# Altium schematic sheet 04-HallEffectSensor.SchDoc
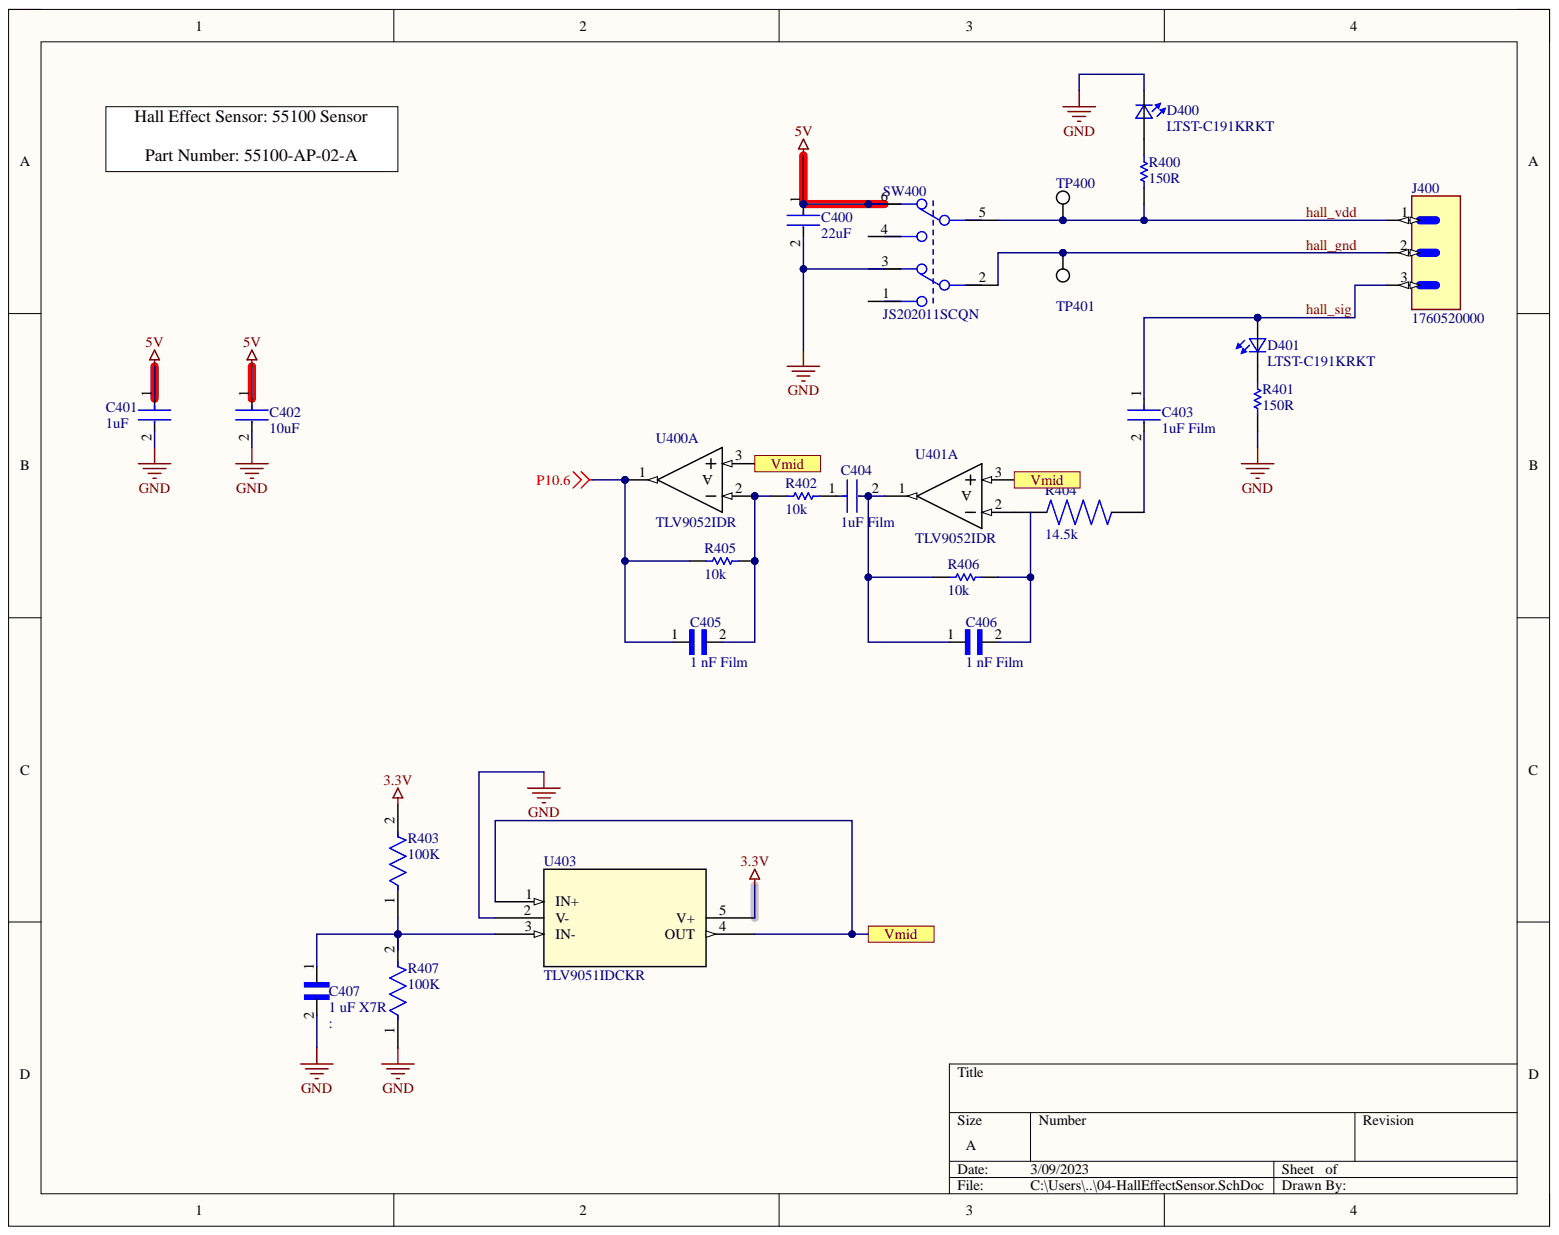
[diagram p0: 04-HallEffectSensor.SchDoc]

## Parts
C400  22uF  [FP-GRM21B-0_15-MFG]
C401  1uF  [FP-CC0805-0_85-MFG]
C402  value 10uF  Capacitance: 10uF  [FP-C0805C-DG-MFG]  (hidden comment: C0805C106K8PACTU)
C403  1uF Film  Capacitance: 1uF  [FP-R82DC4100DQ60J-MFG]
C404  1uF Film  Capacitance: 1uF  [FP-R82DC4100DQ60J-MFG]
C405  1 nF Film  [CAPRR500W50L730T250H650]
C406  1 nF Film  [CAPRR500W50L730T250H650]
C407  1 uF X7R  [CAPC2012X140N]
D400  LTST-C191KRKT  [0603]
D401  LTST-C191KRKT  [0603]
J400  1760520000  [1760520000]
R400  150R  [RESC3216X70X50ML20T25]
R401  150R  [RESC2013X60X40NL20T25]
R402  10k  [RESC2013X60X35ML10T20]
R403  100K  [FP-RC0805-0_6-IPC_C]
R404  14.5k  [RESC2012X60N]
R405  10k  [RESC2013X60X35ML10T20]
R406  10k  [RESC2013X60X35ML10T20]
R407  100K  [FP-RC0805-0_6-IPC_C]
SW400  JS202011SCQN  [FP-JS202011SCQN-MFG]
TP400  5019  [KSTN-5019_V]  (value not drawn)
TP401  5019  [KSTN-5019_V]  (value not drawn)
U400  TLV9052IDR  [D0008A_N]  (part A)
U401  TLV9052IDR  [D0008A_N]  (part A)
U403  TLV9051IDCKR  [SOT_1IDCKR_TEX]

## Ports
Vmid

## Nets
3.3V: R403.2 U403.5(V+)
5V: C400.1 C401.1 C402.1 SW400.6
GND: C400.2 C401.2 C402.2 C407.2 D400.1 R401.1 R407.1 U403.2(V-)
P10.6: C405.1 R405.1 U400.1
hall_gnd: J400.2 SW400.2 TP401.1
hall_sig: C403.1 D401.2 J400.3
hall_vdd: J400.1 R400.1 SW400.5 TP400.1
Vmid: U400.3 U401.3 U403.1(IN+) U403.4(OUT) ; port Vmid
NetC403_2: C403.2 R404.2
NetC404_1: C404.1 R402.2
NetC404_2: C404.2 C406.1 R406.1 U401.1
NetC405_2: C405.2 R402.1 R405.2 U400.2
NetC406_2: C406.2 R406.2
NetC407_1: C407.1 R403.1 R407.2 U403.3(IN-)
NetD400_2: D400.2 R400.2
NetD401_1: D401.1 R401.2
NetR404_1: R404.1 U401.2

Not connected: SW400 1 3 4

## Library data of the parts
C400 = CMP-2007-03385-2: Manufacturer: Murata; Manufacturer Part Number: GRM21BR60J226ME39L; Dielectric: X5R; Material: Ceramic; Tolerance: 20%; Voltage: 6.3V; Capacitance: 22uF; Case Code (Imperial): 0805; Case Code (Metric): 2012; Case/Package: 0805; Category: Ceramic Capacitors; Component Kind: Standard; Construction: Flat; Depth: 1.25mm; Description: 22uF; Height: 1.25mm; Lead Free: Lead Free; Length: 2mm; Library Name: Altium Content Vault; Max Operating Temperature: 85°C; Min Operating Temperature: -55°C; Mount: Surface Mount; Package Quantity: 1; Packaging: Tape and Reel; Pricing 1: 1=0.37000, 10=0.25600, 50=0.17940, 100=0.15380, 500=0.11532, 1000=0.09739 (USD); Radiation Hardening: No; REACH SVHC: No SVHC; Reach SVHC Compliant: Yes; RoHS: Yes; RoHS Compliant: Yes; Series: GRM; Stock 1: 2705091; Supplier 1: Digi-Key; Supplier Part Number 1: 490-1719-1-ND; Termination: SMD/SMT; Thickness: 0.053inch; Voltage Rating: 6.3V; Voltage Rating (DC): 6.3V; Width: 0.049inch
C401 = CMP-03422-000182-1: CAP CER 1UF 10V X7R 0805; Manufacturer: Yageo; Manufacturer Part Number: CC0805KKX7R6BB105; Material: Ceramic; Tolerance: 0.1; Automotive: No; Capacitance: 1 uF; Category: Cap; Component Kind: Standard; ComponentLink2Description: Datasheet; ComponentLink2URL: http://www.yageo.com/documents/recent/UPY-GPHC_Y5V_6.3V-to-50V_8.pdf; Device Class L1: Passive Components; Device Class L2: Capacitors; Device Class L3: Ceramic Capacitors; Digikey Description: CAP CER 1UF 10V X7R 0805; Footprint Url: http://www.yageo.com/exep/pages/download/literatures/UPY-C_GEN_24.pdf; Height: 0.95mm; Ipc Land Pattern Name: CAPC200125X85; Lead Free: Yes; Library Name: Altium Content Vault; Mouser Description: Multilayer Ceramic Capacitors MLCC - SMD/SMT 1.0uF 10V X7R 10%; Octopart: https://octopart.com/cc0805kkx7r6bb105-yageo-42522859; Package: CC0805-0.85; RoHS: TRUE; Temperature: -30°C to +85°C; Temperature Characteristic: X7R; Temperature Range High: +85°C; Temperature Range Low: -30°C; Value: 1 uF; Voltage Rating: 10 V
C402 = CMP-2007-03243-2: CAP CER 10UF 10V X5R 0805; 3DS Part Number: 220-00001; Manufacturer: Kemet; Dielectric Material: Ceramic; Dissipation Factor: 10%; Temperature Coefficient: 15%; Automotive: No; Case Code (Imperial): 0805; Case Code (Metric): 2012; Case/Package: 0805; Category: Cap; Component Kind: Standard; ComponentLink2Description: Datasheet; ComponentLink2URL: https://content.kemet.com/datasheets/KEM_C1006_X5R_SMD.pdf; Construction: Flat; Contact Plating: Tin,Matte; Depth: 1.25mm; Device Class L1: Passive Components; Device Class L2: Capacitors; Device Class L3: Ceramic Capacitors; Dielectric: X5R; Digikey Description: CAP CER 10UF 10V X5R 0805; Height: 1.4mm; Ipc Land Pattern Name: CAPC200125X125; Lead Free: Yes; Length: 2mm; Library Name: Altium Content Vault; Manufacturer 1: KEMET; Manufacturer Part Number: C0805C106K8PACTU; Manufacturer Part Number 1: C0805C106K8PACTU; Material: Ceramic; Max Operating Temperature: 85°C; Min Operating Temperature: -55°C; Mount: Surface Mount; Mouser Description: CAP CER 10UF 10V X5R 0805; Number of Pins: 2; Octopart: https://octopart.com/c0805c106k8pactu-kemet-98697; Package: C0805C-DG; Package Quantity: 1; Packaging: Tape and Reel; Pricing 1: 1=0.14000, 10=0.10300, 50=0.05840, 100=0.04830, 500=0.03388, 1000=0.02805 (USD); Radiation Hardening: No; REACH SVHC: No SVHC; RoHS: TRUE; RoHS Compliant: Yes; Series: C; Stock 1: 8631846; Supplier 1: Digi-Key; Supplier Part Number 1: 399-C0805C106K8PAC7800CT-ND; Temperature: -55°C to +85°C; Temperature Characteristic: X5R; Temperature Range High: +85°C; Temperature Range Low: -55°C; Termination: SMD/SMT; Thickness: 0.055inch; Tolerance: 10%; Voltage: 10V; Voltage Rating: 10V; Voltage Rating (DC): 10V; Width: 1.2mm
C403 C404 = CMP-03022-000017-1: CAP FILM 1UF 5% 63VDC RADIAL; Dielectric: PET; Dissipation Factor: 80%; Insulation Resistance: 30R; Lead Diameter: 0.5mm; Life (Hours): 2000Hour; Type: General Purpose; Voltage: 63V; Voltage Rating (AC): 40V; Case/Package: Radial; Component Kind: Standard; Construction: RADIAL; Contact Plating: Tin; Depth: 5mm; Dielectric Material: PET; Height - Seated (Max): 0.398inch; Lead Pitch: 5mm; Length: 7.2mm; Library Name: Altium Content Vault; Max Operating Temperature: 105°C; Min Operating Temperature: -55°C; Mount: Through Hole; Package Quantity: 1700; Packaging: Tape and Reel; Radiation Hardening: No; REACH SVHC: No SVHC; RoHS Compliant: Yes; Series: R82; Termination: Radial; Tolerance: 5%; Voltage Rating: 63V; Voltage Rating (DC): 63V; Width: 5mm
C405 C406 = B32529C0102K289: 1000 pF Film Capacitor 40V 63V Polyester, Polyethylene Terephthalate (PET), Metallized - Stacked Radial; MF: TDK; Check_prices: https://www.snapeda.com/parts/B32529C0102K289/TDK/view-part/?ref=eda; Component Kind: Standard; Digi-Key_Part_Number: 495-2456-1-ND; Library Name: B32529C0102K289.SchLib; MP: B32529C0102K289; Package: None; Purchase-URL: https://pricing.snapeda.com/search/part/B32529C0102K289/?ref=eda; SnapEDA_Link: https://www.snapeda.com/parts/B32529C0102K289/TDK/view-part/?ref=snap; Supplier 1: Digi-Key; Supplier Part Number 1: 495-2456-1-ND
C407 = CL21B105KAFNNNE: 1ÂµF Â±10% 25V Ceramic Capacitor X7R 0805 (2012 Metric); MF: Samsung Electro-Mechanics; Arrow_Electronics-Purchase-URL: https://snapeda.com/shop?store=Arrow+Electronics&id=544120; Arrow_Europe-Purchase-URL: https://snapeda.com/shop?store=Arrow+Europe&id=544120; Component Kind: Standard; Digi-Key_Part_Number: 1276-1066-1-ND; DigiKey-Purchase-URL: https://snapeda.com/shop?store=DigiKey&id=544120; Library Name: CL21B105KAFNNNE.SchLib; MP: CL21B105KAFNNNE; Package: 0805 Samsung; SnapEDA_Link: https://www.snapeda.com/parts/CL21B105KAFNNNE/Samsung%20Electro-Mechanics/view-part/544120/?ref=snap; Supplier 1: Digi-Key; Supplier Part Number 1: 1276-1066-1-ND
D400 D401 = LTST-C191KRKT: http://octopart.com/ltst-c190krkt-lite-on-549893; Availability: Unavailable; Component Kind: Standard; Library Name: LTST-C191KRKT.SchLib; MP: LTST-C191KRKT; Package: None; Price: None; Supplier 1: Digi-Key; Supplier Part Number 1: 160-1447-1-ND
J400 = 1760520000: 3 Position Wire to Board Terminal Block Horizontal with Board 0.200 _5.08mm_ Through Hole; MF: Weidmuller; Availability: Unavailable; Component Kind: Standard; Library Name: SnapEDAsch.SchLib; MP: 1760520000; Package: None; Price: None; SnapEDA_Link: https://www.snapeda.com/parts/1760520000/Weidmuller/view-part/423520/?ref=snap; Supplier 1: Digi-Key; Supplier Part Number 1: 281-1883-ND
R400 = CMP-2003-04422-1: Manufacturer: Panasonic; Part Number: ERA8AEB151V; Component Kind: Standard; Library Name: Altium Content Vault
R401 = CMP-2001-00596-1: Manufacturer: Panasonic; Part Number: ERA6AEB151V; Component Kind: Standard; Library Name: Altium Content Vault
R402 R405 R406 = CMP-2000-05025-1: Chip Resistor, 10 KOhm, +/- 5%, 0.125 W, -55 to 155 degC, 0805 (2012 Metric), RoHS, Tape and Reel; Manufacturer: Yageo; Power Rating: 250mW; Product Range: RCSeries; Resistance: 10kohm; Resistor Case Style: 0805[2012Metric]; Resistor Element Type: ThickFilm; SVHC: ToBeAdvised; Temperature Coefficient: ±100ppm/degC; Voltage Rating: 150V; Automotive Qualification Standard: -; Component Kind: Standard; Datasheet URL: http://www.yageo.ru/pdf/RC0805.pdf; Datasheet Version: REV. 2, 09/2004; Library Name: Altium Content Vault; Manufacturer URL: http://www.yageo.com/; MSL: MSL1-Unlimited; Package Description: 2-Pin Surface Mounted Device, Body 2 x 1.25 mm; Package Reference: 805; Packaging: CutTape; Resistance Tolerance: ±5%
R403 R407 = CMP-2100-03668-5: RES 100K OHM 1% 1/8W 0805; Max Power Dissipation: 125mW; Resistance: 100kR; Temperature Coefficient: 100ppm/°C; Voltage Rating: 150V; Case Code (Imperial): 0805; Case Code (Metric): 2012; Case/Package: 0805; Component Kind: Standard; Construction: Rectangular; Depth: 1.25mm; Features: Moisture Resistant; Height: 0.024inch; Lead Free: Lead Free; Lead Pitch: 0.4mm; Length: 0.079inch; Library Name: Altium Content Vault; Max Operating Temperature: 155°C; Military Standard: Not; Min Operating Temperature: -55°C; Number of Pins: 2; Number of Terminations: 2; Package Quantity: 1; Packaging: Tape and Reel; Power Rating: 125mW; Resistance Tolerance: 1%; Resistor Type: Thick Film; RoHS Compliant: Yes; Series: RC; Size Code: 0805; Supplier: Digi-Key; Supplier Part Number: 311-100KCRCT-ND; Technology: THICK FILM; Termination: SMD/SMT; Tolerance: 1%; Voltage Rating (DC): 150V; Width: 0.049inch
R404 = RT0805DRE0714K5L: Availability: Unavailable; Component Kind: Standard; Library Name: RT0805DRE0714K5L.SchLib; MP: RT0805DRE0714K5L; Package: None; Price: None; Supplier 1: Digi-Key; Supplier Part Number 1: 311-2788-1-ND
SW400 = CMP-2000-05087-3: SWITCH SLIDE DPDT 300MA 6V; Actuator Color: Black; Actuator Length: 2mm; Actuator Material: Nylon; Actuator Type: Standard; Contact Resistance: 70mR; Electrical Life: 5000Cycles; Switch Function: On-On; Component Kind: Standard; Contact Current Rating: 300mA; Contact Material: Copper Alloy; Contact Plating: Silver; Current Rating: 300mA; Depth: 7.5mm; Flammability Rating: UL94 V-2; Housing Material: Nylon; Lead Free: Lead Free; Length: 9mm; Library Name: Altium Content Vault; Max Current Rating: 300mA; Max Operating Temperature: 85°C; Max Voltage Rating (DC): 6V; Min Operating Temperature: -20°C; Mount: Surface Mount; Orientation: Vertical; Package Quantity: 1; Packaging: Tape and Reel; Radiation Hardening: No; REACH SVHC: No SVHC; Series: JS; Switch Travel: 2mm; Termination: Gull Wing; Throw Configuration: DPDT; Voltage Rating (DC): 6V
TP400 TP401 = CMP-1672-00008-1: Test Point, 1 Position SMD, RoHS, Tape and Reel; Manufacturer 1: Keystone Electronics; Category: Connectors; Component Kind: Standard; ComponentLink1Description: Manufacturer URL; ComponentLink1URL: http://www.keyelco.com/; ComponentLink2Description: Datasheet; ComponentLink2URL: http://www.keyelco.com/product-pdf.cfm?p=1357; ComponentLink3Description: https://datasheet.ciiva.com/16318/m60-2p61-16318395.pdf?src-supplier=Future+Electronics; ComponentLink3URL: https://datasheet.ciiva.com/16318/m60-2p61-16318395.pdf?src-supplier=Future+Electronics; ComponentLink4Description: https://datasheet.ciiva.com/16885/70182998-16885945.pdf?src-supplier=Allied+Electronics; ComponentLink4URL: https://datasheet.ciiva.com/16885/70182998-16885945.pdf?src-supplier=Allied+Electronics; ComponentLink5Description: https://datasheet.ciiva.com/14848/m60-2p61-14848437.pdf?src-supplier=Digi-Key; ComponentLink5URL: https://datasheet.ciiva.com/14848/m60-2p61-14848437.pdf?src-supplier=Digi-Key; ComponentLink6Description: https://datasheet.ciiva.com/14848/m60-2p61-14848438.pdf?src-supplier=Digi-Key; ComponentLink6URL: https://datasheet.ciiva.com/14848/m60-2p61-14848438.pdf?src-supplier=Digi-Key; ComponentLink7Description: https://datasheet.ciiva.com/14848/m60-2p61-14848439.pdf?src-supplier=Digi-Key; ComponentLink7URL: https://datasheet.ciiva.com/14848/m60-2p61-14848439.pdf?src-supplier=Digi-Key; Contact Material: Bronze; Contact Plating: Silver; DatasheetVersion: 03/2014; Height: 0.09inch; Lead Free: Lead Free; Library Name: Altium Content Vault; Manufacturer Part Number 1: 5019; Material: Bronze; Mount: Surface Mount; Mounting Technology: Surface Mount; Number of Pins: 1; Package Quantity: 1000; PackageDescription: 1-Pin Surface Mount Device, Body 3.81 x 2.03 mm; PackageReference: 5019; Packaging: Tape and Reel; Pins: 1; Pricing 1: 1=0.34000, 25=0.27360, 100=0.22340, 250=0.20064, 500=0.19152 (USD); REACH SVHC: No SVHC; RoHS: Yes; RoHS Compliant: Yes; RoHSCompliant: True; Series: 5019; Stock 1: 1316816; Supplier 1: Digi-Key; Supplier Part Number 1: 36-5019CT-ND; Termination: SMD/SMT
U400 U401 = TLV9052IDR: 5MHz 15V/us High Slew-Rate RRIO Operational Amplifier 8-Pin SOIC T/R; Manufacturer: Texas Instruments; Category: Amplifiers - Op Amps, Buffer, Instrumentation; Component Kind: Standard; ComponentLink1Description: http://www.ti.com/general/docs/suppproductinfo.tsp?distId=10&amp;gotoUrl=http%3A%2F%2Fwww.ti.com%2Flit%2Fgpn%2Ftlv9052&src-supplier=Digi-Key; ComponentLink1URL: http://www.ti.com/general/docs/suppproductinfo.tsp?distId=10&amp;gotoUrl=http%3A%2F%2Fwww.ti.com%2Flit%2Fgpn%2Ftlv9052&src-supplier=Digi-Key; Copyright: Copyright (C) 2023 Ultra Librarian. All rights reserved.; Library Name: TLV9052IDR.SchLib; Manufacturer Part Number: TLV9052IDR; Manufacturer_Part_Number: TLV9052IDR; Mfr_Name: Texas Instruments; Pricing: 1=0.93000, 10=0.82200, 25=0.77240, 100=0.63020, 250=0.58540, 500=0.49820, 1000=0.39856 (USD); RefDes: RefDes; RoHS: Yes; RoHS Compliant: Yes; Stock: 44741; Supplier: Digi-Key; Supplier Part Number: 296-53049-1-ND; Type: DEV
  U400: Supplier 1: Digi-Key; Supplier Part Number 1: 296-53049-1-ND
U403 = TLV9051IDCKR: Single 5-MHz, 15-V/µs high slew-rate, RRIO op amp 5-SC70 -40 to 125; Manufacturer: Texas Instruments; Category: Amplifiers - Op Amps, Buffer, Instrumentation; Component Kind: Standard; Copyright: Copyright (C) 2023 Ultra Librarian. All rights reserved.; Library Name: TLV9051IDCKR.SchLib; Manufacturer Part Number: TLV9051IDCKR; Manufacturer_Part_Number: TLV9051IDCKR; Mfr_Name: Texas Instruments; Pricing: 1=0.62000, 10=0.54200, 25=0.50920, 100=0.41570, 250=0.38612, 500=0.32860, 1000=0.26288 (USD); RefDes: RefDes; RoHS: Yes; Stock: 2075; Supplier: Digi-Key; Supplier 1: Digi-Key; Supplier Part Number: 296-TLV9051IDCKRCT-ND; Supplier Part Number 1: 296-TLV9051IDCKRCT-ND; Type: DEV
